# Altium schematic sheet 7) Modbus.SchDoc("7) Modbus")
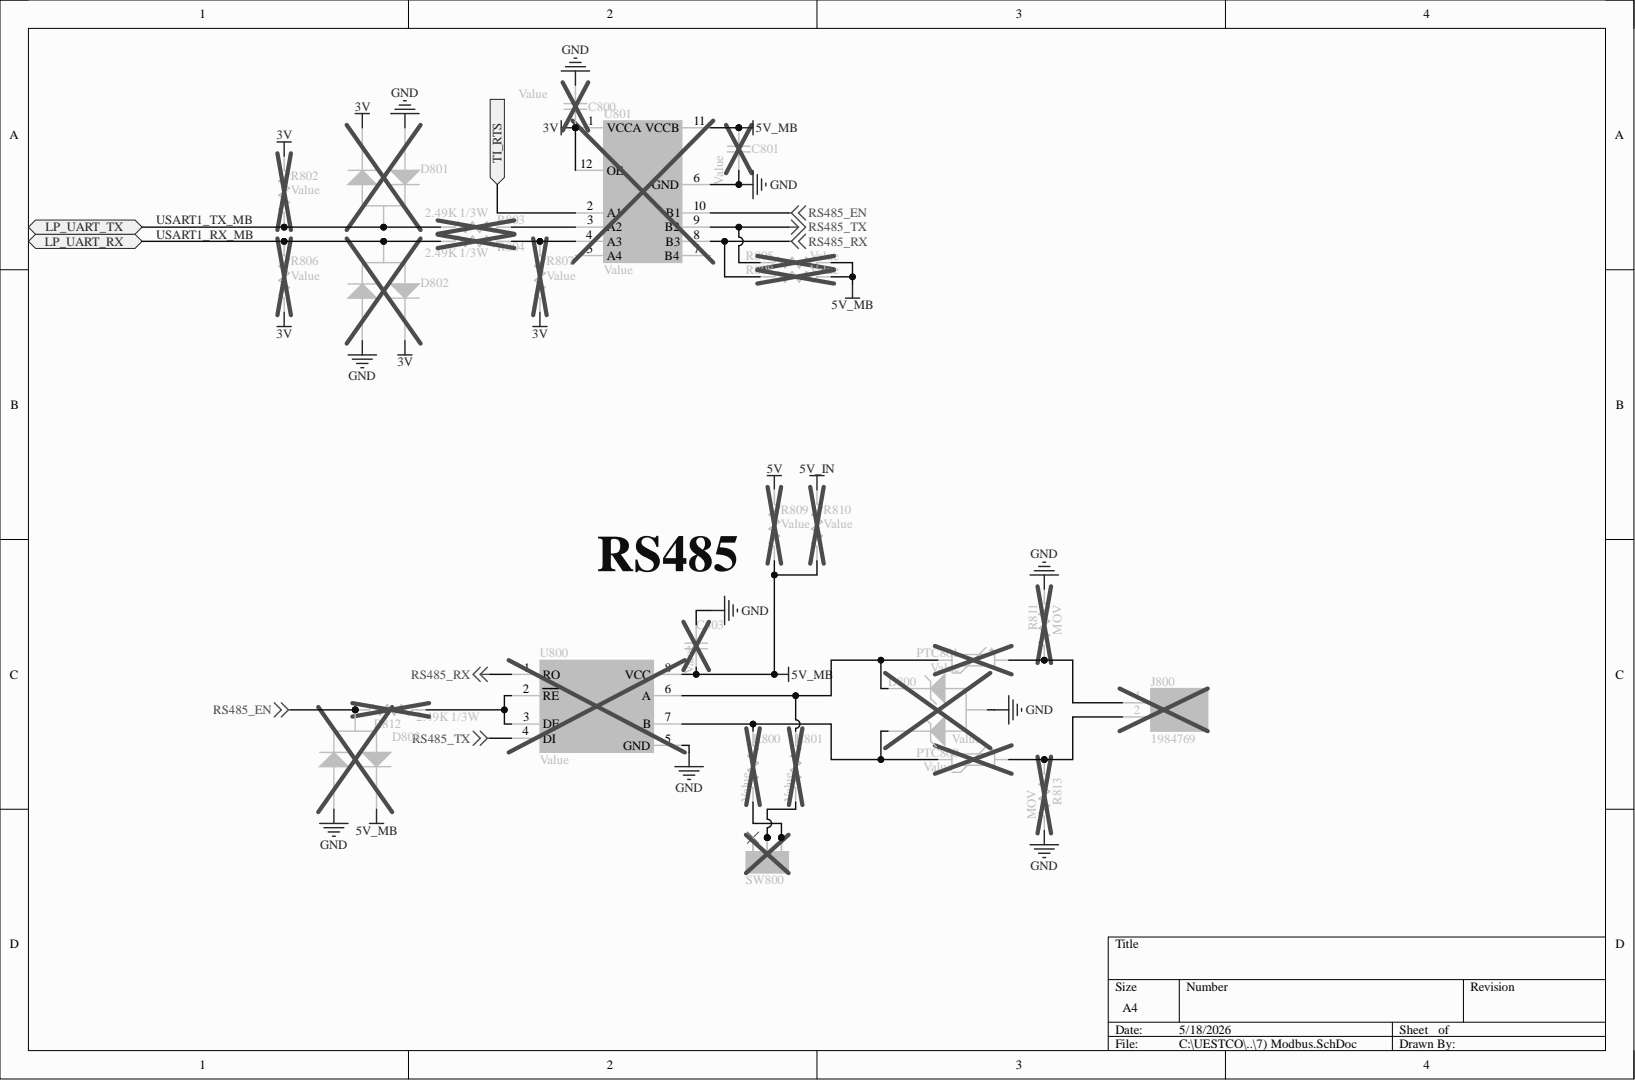
[diagram p0: 7) Modbus.SchDoc]

## Parts
C800  100nf 50V  [C0402]
C801  100nf 50V  [C0402]
C803  100nf 50V  [C0402]
D800  MMBZ15VAL,215  [SOT23]
D801  BAT54S_R1_00001  [SOT23]  (value not drawn)
D802  BAT54S_R1_00001  [SOT23]  (value not drawn)
D803  BAT54S_R1_00001  [SOT23]  (value not drawn)
J800  1984769  [1984769]
PTC800  PTC 15V 100mA  [PTC 0603]
PTC801  PTC 15V 100mA  [PTC 0603]
R800  60R4 %1  [R0603]
R801  60R4 %1  [R0603]
R802  10k %5  [R0402]
R803  2.49K 1/3W  [R0603]
R804  2.49K 1/3W  [R0603]
R805  10k %5  [R0402]
R806  10k %5  [R0402]
R807  10k %5  [R0402]
R808  10k %5  [R0402]
R809  0R  [R0402]
R810  0R  [R0402]
R811  MOV  [R0603]
R812  2.49K 1/3W  [R0603]
R813  MOV  [R0603]
SW800  SW SLIDE  [JS202011JCQN]  (value not drawn)
U800  SN65HVD485EDR  [8-SOIC]
U801  TXU0204RUTR  [12-UQFN (1.7x2)]

## Ports
LP_UART_RX, LP_UART_TX, TI_RTS

## Nets
3V: C800.1 D801.2 D802.2 R802.1 R806.1 R807.1 U801.1(VCCA) U801.12(OE)
5V: R809.2
5V_IN: R810.2
5V_MB: C801.2 C803.1 D803.2 R805.1 R808.1 R809.1 R810.1 U800.8(VCC) U801.11(VCCB)
GND: C800.2 C801.1 C803.2 D800.3 D801.1 D802.1 D803.1 R811.2 R813.1 U800.5(GND) U801.6(GND)
RS485_EN: D803.3 R812.2 U801.10(B1)
RS485_RX: R808.2 U800.1(RO) U801.8(B3)
RS485_TX: R805.2 U800.4(DI) U801.9(B2)
TI_RTS: U801.2(A1) ; port TI_RTS
USART1_RX_MB: D802.3 R804.2 R806.2 ; port LP_UART_RX
USART1_TX_MB: D801.3 R802.2 R803.2 ; port LP_UART_TX
NetD800_1: D800.1 PTC800.1 R800.2 U800.7(B)
NetD800_2: D800.2 PTC801.1 R801.2 U800.6(A)
NetJ800_1: J800.1 PTC801.2 R811.1
NetJ800_2: J800.2 PTC800.2 R813.2
NetR800_1: R800.1 SW800.3 SW800.6
NetR801_1: R801.1 SW800.2 SW800.5
NetR803_1: R803.1 U801.3(A2)
NetR804_1: R804.1 R807.2 U801.4(A3)
NetR812_1: R812.1 U800.2(RE) U800.3(DE)
NetSW800_1: SW800.1 SW800.4

Not connected: U801 5(A4) 7(B4)

## Library data of the parts
C800 C801 C803 = CAP 100nf 50V %10 0402: CAP CER 0.1UF 50V X5R 0402; Manufacturer Part Number: CC0402KRX5R9BB104; Component Kind: Standard; Library Name: PCBGSM910G2.SCHLIB; Value: 100nf 50V
D800 = TVS MMBZ15VAL,215: TVS DIODE 12VWM 21VC TO236AB; Component Kind: Standard; Library Name: PCBGSM910G2.SCHLIB; Manufacturer Part Number: MMBZ15VAL,215; Value: MMBZ15VAL,215
D801-D803 = BAT54S_R1_00001: TVS DIODE 12VWM 21VC TO236AB; Component Kind: Standard; Library Name: PCBGSM910G2.SCHLIB; Manufacturer Part Number: BAT54S_R1_00001; Value: BAT54S_R1_00001
J800 = 1984769: PCB terminal block, nominal current: 17.5 A, rated voltage (III/2): 200 V, nominal cross section: 1.5 mm?, Number of potentials: 2, Number of rows: 1, Number of positions per row: 2, product range: PT 1,5/..-V, pitch: 3.5 mm, connection method: Screw connection with wire protector, mounting: Wave soldering, conductor/PCB connection direction: 90 ?, color: green, Pin layout: Linear pinning, Solder pin [P]: 4.5 mm, type of packaging: packed in cardboard; Manufacturer_Name: Phoenix Contact; Geometry.Height: 7.7mm; Arrow Part Number: 1984769; Arrow Price/Stock: https://www.arrow.com/en/products/1984769/phoenix-contact?region=nac; Component Kind: Standard; Datasheet Link: https://www.phoenixcontact.com/en-us/products/printed-circuit-board-terminal-pt-15-2-35-v-1984769?type=pdf; Library Name: 1984769.SchLib; Manufacturer_Part_Number: 1984769; Mouser Part Number: 651-1984769; Mouser Price/Stock: https://www.mouser.co.uk/ProductDetail/Phoenix-Contact/1984769?qs=8yqok1MdJSSpxPAqN5U7Uw%3D%3D
PTC800 PTC801 = PTC 15V 100mA: PTC RESET FUSE 15V 100MA 0603; Manufacturer Part Number: 0ZCM0010FF2G; Component Kind: Standard; Library Name: PCBGSM910G2.SCHLIB; Value: PTC 15V 100mA
R800 R801 = RES 60R4 %1 1/10W 0603: RES SMD 60.4 OHM 1% 1/10W 0603; Manufacturer Part Number: RC0603FR-0760R4L; Component Kind: Standard; Library Name: PCBGSM910G2.SCHLIB; Value: 60R4 %1
R802 R805-R808 = RES 10k %5 1/16W 0402: RES SMD 10K OHM 5% 1/16W 0402; Manufacturer Part Number: RC0402JR-0710KL; Component Kind: Standard; Library Name: PCBGSM910G2.SCHLIB; Value: 10k %5
R803 R804 R812 = RES 0R 1/10W 0603: RES SMD 0 OHM JUMPER 1/10W 0603; Manufacturer Part Number: CRCW06032K49FKEAHP; Value: RES SMD 2.49K OHM 1% 1/3W 0603; Component Kind: Standard; Library Name: PCBGSM910G2.SCHLIB
R809 R810 = RES 0R 1/16W 0402: RES SMD 0 OHM JUMPER 1/16W 0402; Manufacturer Part Number: RC0402JR-070RL; Component Kind: Standard; Library Name: PCBGSM910G2.SCHLIB; Value: 0R
R811 R813 = RES 0R 1/10W 0603: VARISTOR 13V 30A 0603; Manufacturer Part Number: CG0603MLA-5.5ME; Value: 13V 30A; Component Kind: Standard; Library Name: PCBGSM910G2.SCHLIB
SW800 = SW JS202011JCQN: SWITCH SLIDE DPDT 300MA 6V; Component Kind: Standard; Library Name: PCBGSM910G2.SCHLIB; Manufacturer Part Number: JS202011JCQN; Value: SW SLIDE
U800 = RS485/RS422 SN65HVD485EDR: 1/1 Transceiver Half RS422, RS485 8-SOIC; Component Kind: Standard; Library Name: PCBGSM910G2.SCHLIB; Manufacturer Part Number: SN65HVD485EDR; Value: SN65HVD485EDR
U801 = Level Shifter TXU0204RUTR: IC TRANSLATOR UNIDIR 12UQFN; Component Kind: Standard; Library Name: PCBGSD920G2B1.SCHLIB; Manufacturer Part Number: TXU0204RUTR; Value: TXU0204RUTR
